# Altium schematic sheet [8]MIPI_CSI.SchDoc
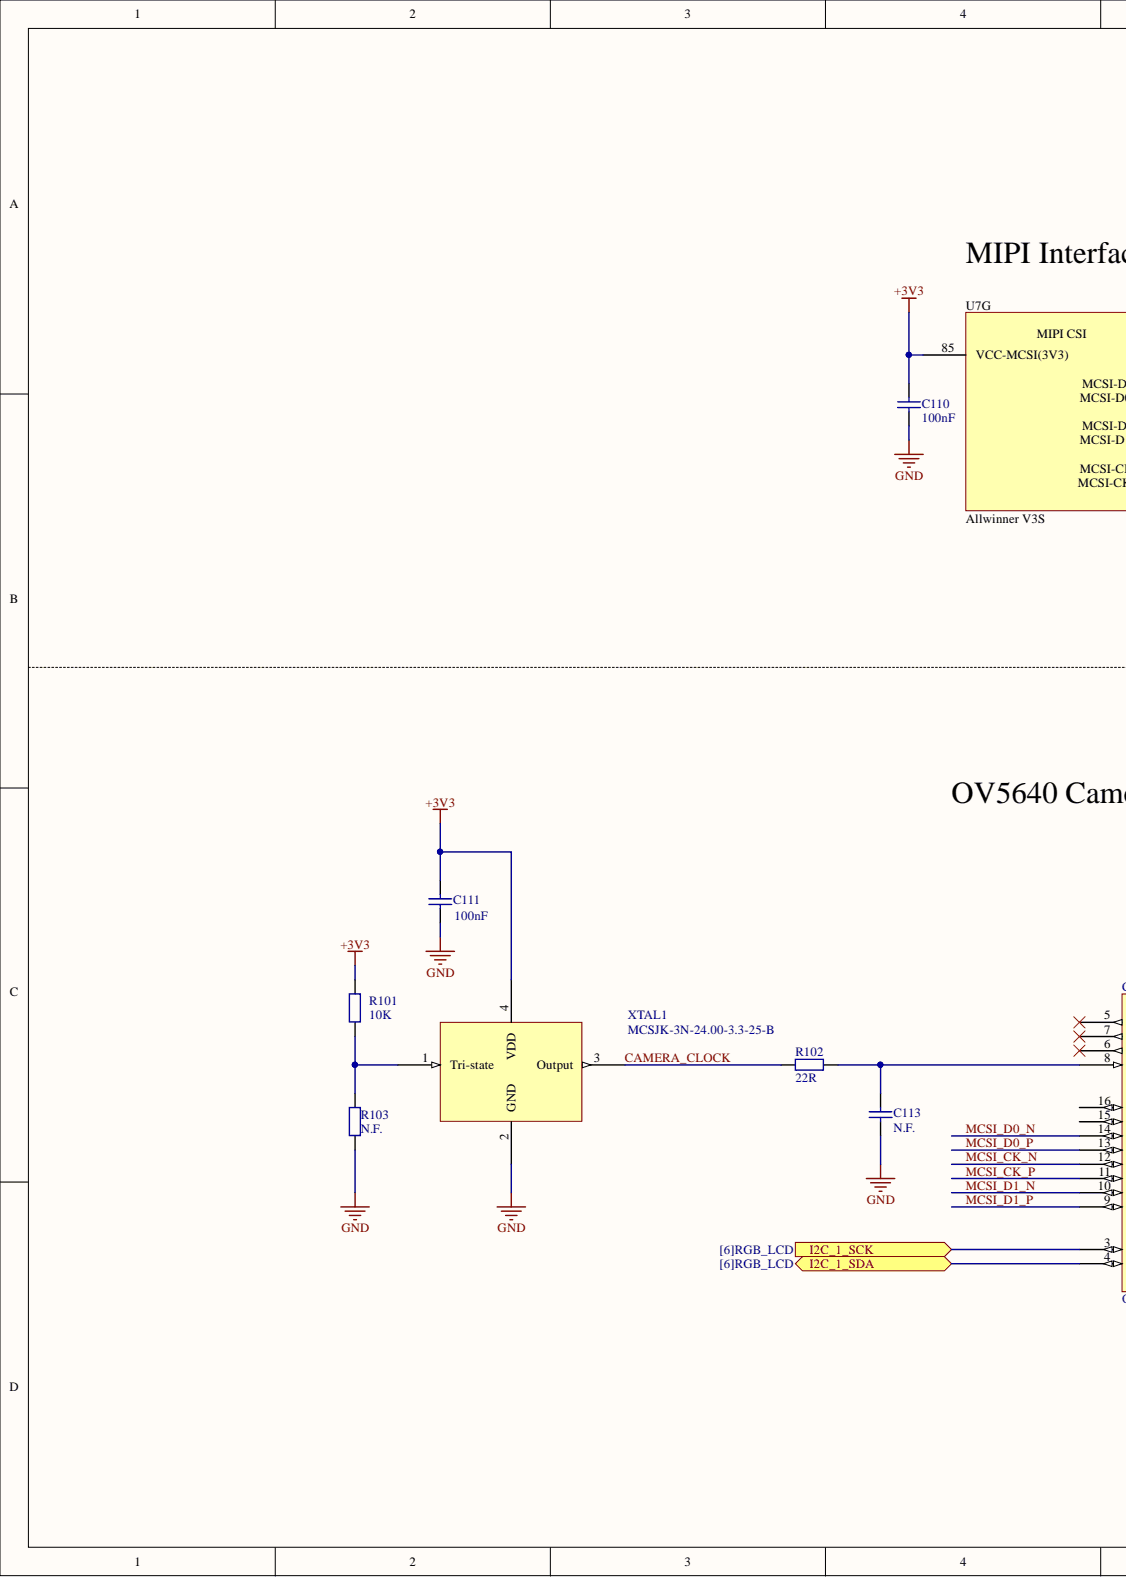
[diagram p0: [8]MIPI_CSI.SchDoc, left]
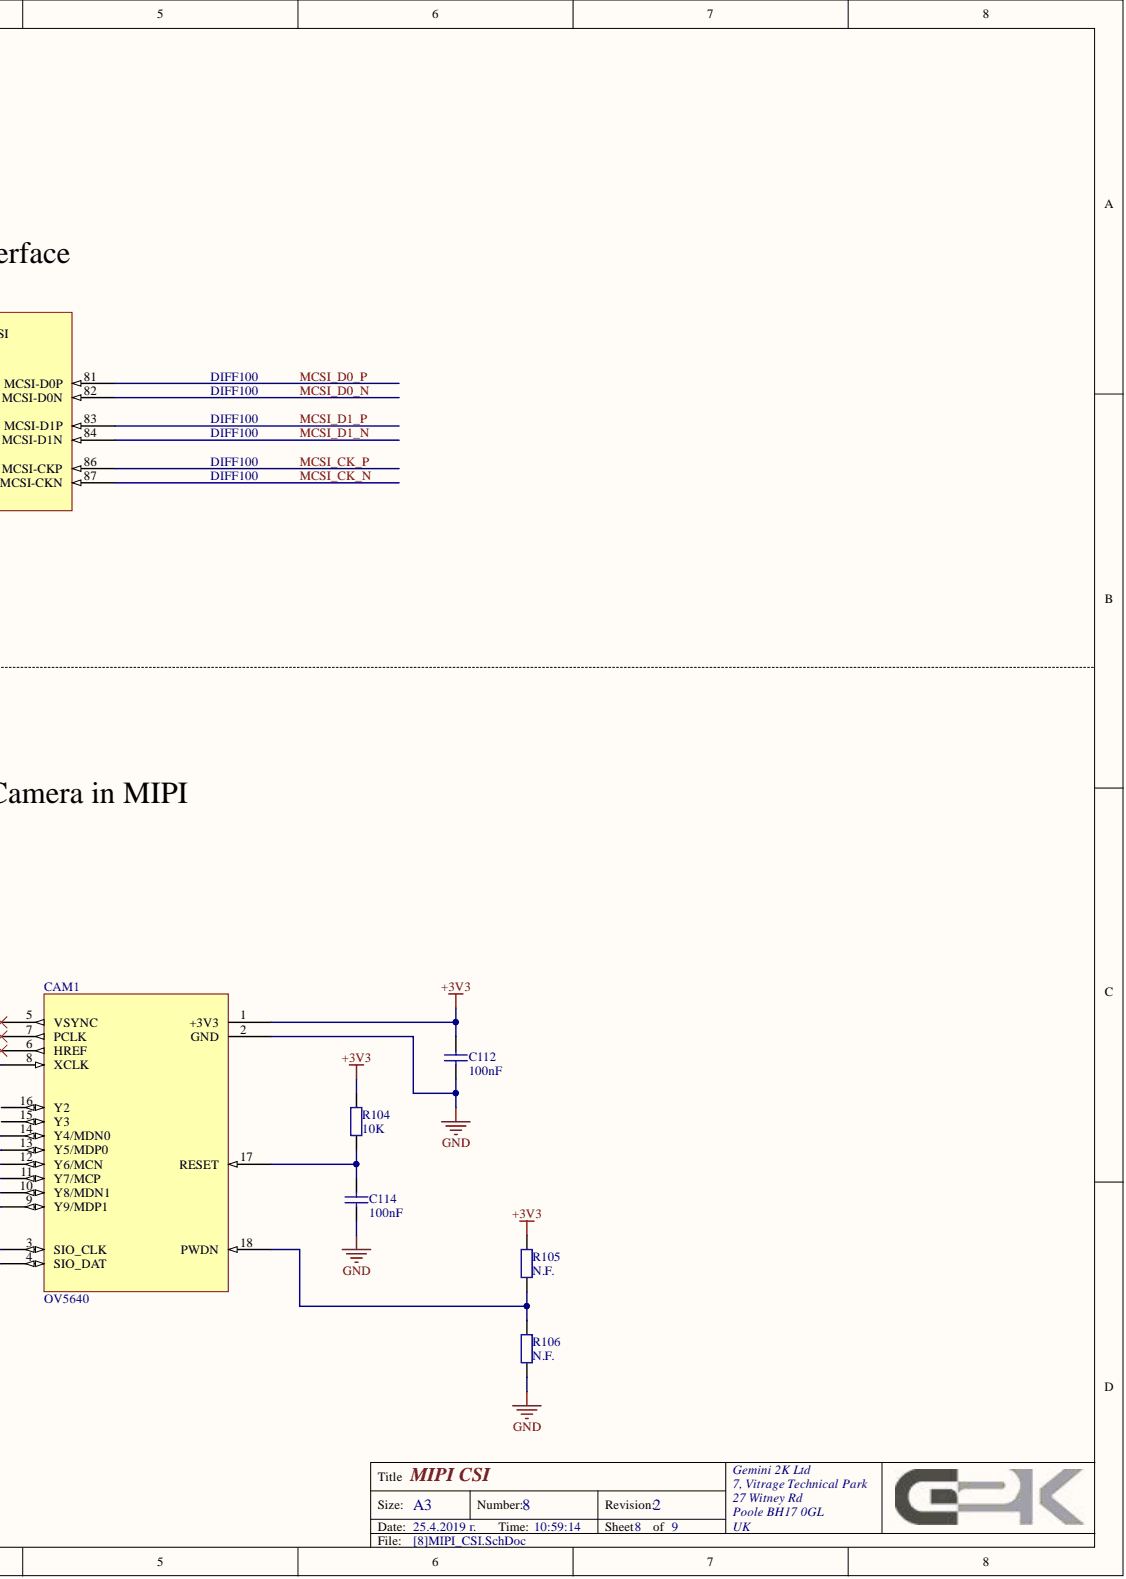
[diagram p1: [8]MIPI_CSI.SchDoc, right]

## Parts
C110  value 100nF  [1608[0603]]  (hidden comment: 0603)
C111  value 100nF  [1608[0603]]  (hidden comment: 0603)
C112  value 100nF  [1608[0603]]  (hidden comment: 0603)
C113  value N.F.  [1608[0603]]  (hidden comment: 0603)
C114  value 100nF  [1608[0603]]  (hidden comment: 0603)
CAM1  OV5640
R101  value 10K  [J1-0603]  (hidden comment: 0603)
R102  value 22R  [J1-0603]  (hidden comment: 0603)
R103  value N.F.  [J1-0603]  (hidden comment: 0603)
R104  value 10K  [J1-0603]  (hidden comment: 0603)
R105  value N.F.  [J1-0603]  (hidden comment: 0603)
R106  value N.F.  [J1-0603]  (hidden comment: 0603)
U7  Allwinner V3S  Manufacturer Name: Allwinner  Manufacturer Part No.: V3S  [LQFP128 E-PAD - Low Density]  (part G)
XTAL1  MCSJK-3N-24.00-3.3-25-B  [3.1mm x 2.5mm Oscilator]

## Ports
I2C_1_SCK, I2C_1_SDA

## Nets
+3V3: C110.2 C111.2 C112.2 CAM1.1(+3V3) R101.2 R104.2 R105.2 U7.85(VCC-MCSI(3V3)) XTAL1.4(VDD)
GND: C110.1 C111.1 C112.1 C113.1 C114.1 CAM1.2(GND) R103.1 R106.1 XTAL1.2(GND)
CAMERA_CLOCK: R102.1 XTAL1.3(Output)
I2C_1_SCK: CAM1.3(SIO_CLK) ; port I2C_1_SCK
I2C_1_SDA: CAM1.4(SIO_DAT) ; port I2C_1_SDA
MCSI_CK_N: CAM1.12(Y6/MCN) U7.87(MCSI-CKN)
MCSI_CK_P: CAM1.11(Y7/MCP) U7.86(MCSI-CKP)
MCSI_D0_N: CAM1.14(Y4/MDN0) U7.82(MCSI-D0N)
MCSI_D0_P: CAM1.13(Y5/MDP0) U7.81(MCSI-D0P)
MCSI_D1_N: CAM1.10(Y8/MDN1) U7.84(MCSI-D1N)
MCSI_D1_P: CAM1.9(Y9/MDP1) U7.83(MCSI-D1P)
NetC113_2: C113.2 CAM1.8(XCLK) R102.2
NetC114_2: C114.2 CAM1.17(RESET) R104.1
NetCAM1_18: CAM1.18(PWDN) R105.1 R106.2
NetR101_1: R101.1 R103.2 XTAL1.1(Tri-state)

Not connected: CAM1 5(VSYNC) 6(HREF) 7(PCLK) 15(Y3) 16(Y2)

## Library data of the parts
C110-C112 C114 = Cap: Capacitor; Note: We have them in Bulgaria; Case Code (in): 0603; Component Kind: Standard; Distributor Link: http://uk.farnell.com/kemet/c0603x104k4ractu/cap-mlcc-x7r-100nf-16v-0603/dp/1414027; LatestRevisionDate: 17-Jul-2002; LatestRevisionNote: Re-released for DXP Platform.; Library Name: Miscellaneous Devices.IntLib; PackageDescription: Radial Cap, Thru-Hole; 2 Leads; 0.3 in Pin Spacing; PackageReference: RAD-0.3; Published: 8-Jun-2000; Signal Integrity: Cap; Simulation: CAP; Publisher: Altium Limited
C113 = Cap: Capacitor; Case Code (in): 0603; Component Kind: Standard; LatestRevisionDate: 17-Jul-2002; LatestRevisionNote: Re-released for DXP Platform.; Library Name: Miscellaneous Devices.IntLib; PackageDescription: Radial Cap, Thru-Hole; 2 Leads; 0.3 in Pin Spacing; PackageReference: RAD-0.3; Published: 8-Jun-2000; Signal Integrity: Cap; Simulation: CAP; Publisher: Altium Limited
CAM1 = OV5640 Camera: Value: N.F.; Component Kind: Standard; Library Name: PapayaPi.SchLib
R101 R103-R106 = Res2: Resistor; Case Code (in): 0603; Component Kind: Standard; LatestRevisionDate: 17-Jul-2002; LatestRevisionNote: Re-released for DXP Platform.; Library Name: Miscellaneous Devices.IntLib; PackageDescription: Axial Device, Thru-Hole; 2 Leads; 0.4 in Pin Spacing; PackageReference: AXIAL-0.4; Published: 8-Jun-2000; Signal Integrity: Res; Simulation: RESISTOR; Publisher: Altium Limited
  R101 R104: Distributor Link: http://uk.farnell.com/multicomp/mcwr06x1002ftl/res-thick-film-10k-1-0-1w-0603/dp/2447230
R102 = Res2: Resistor; Note: We have them in Bulgaria; Case Code (in): 0603; Component Kind: Standard; Distributor Link: https://uk.farnell.com/multicomp/mcwr06x22r0ftl/res-22r-1-0-1w-thick-film/dp/2447301; LatestRevisionDate: 17-Jul-2002; LatestRevisionNote: Re-released for DXP Platform.; Library Name: Miscellaneous Devices.IntLib; PackageDescription: Axial Device, Thru-Hole; 2 Leads; 0.4 in Pin Spacing; PackageReference: AXIAL-0.4; Published: 8-Jun-2000; Signal Integrity: Res; Simulation: RESISTOR; Publisher: Altium Limited
XTAL1 = ECS-1612MV: Manufacturer Name: MULTICOMP; Component Kind: Standard; Distributor Link: https://uk.farnell.com/multicomp/mcsjk-3n-24-00-3-3-25-b/oscillator-24mhz-3-2mm-x-2-5mm/dp/2854298RL; Manufacturer Part No.: MCSJK-3N-24.00-3.3-25-B; Library Name: PapayaPi.SchLib
U7 = Allwinner V3S: Component Kind: Standard; Library Name: Allwinner.SchLib
